# Altium schematic sheet 01_Power_Control.SchDoc(01_Power_Control)
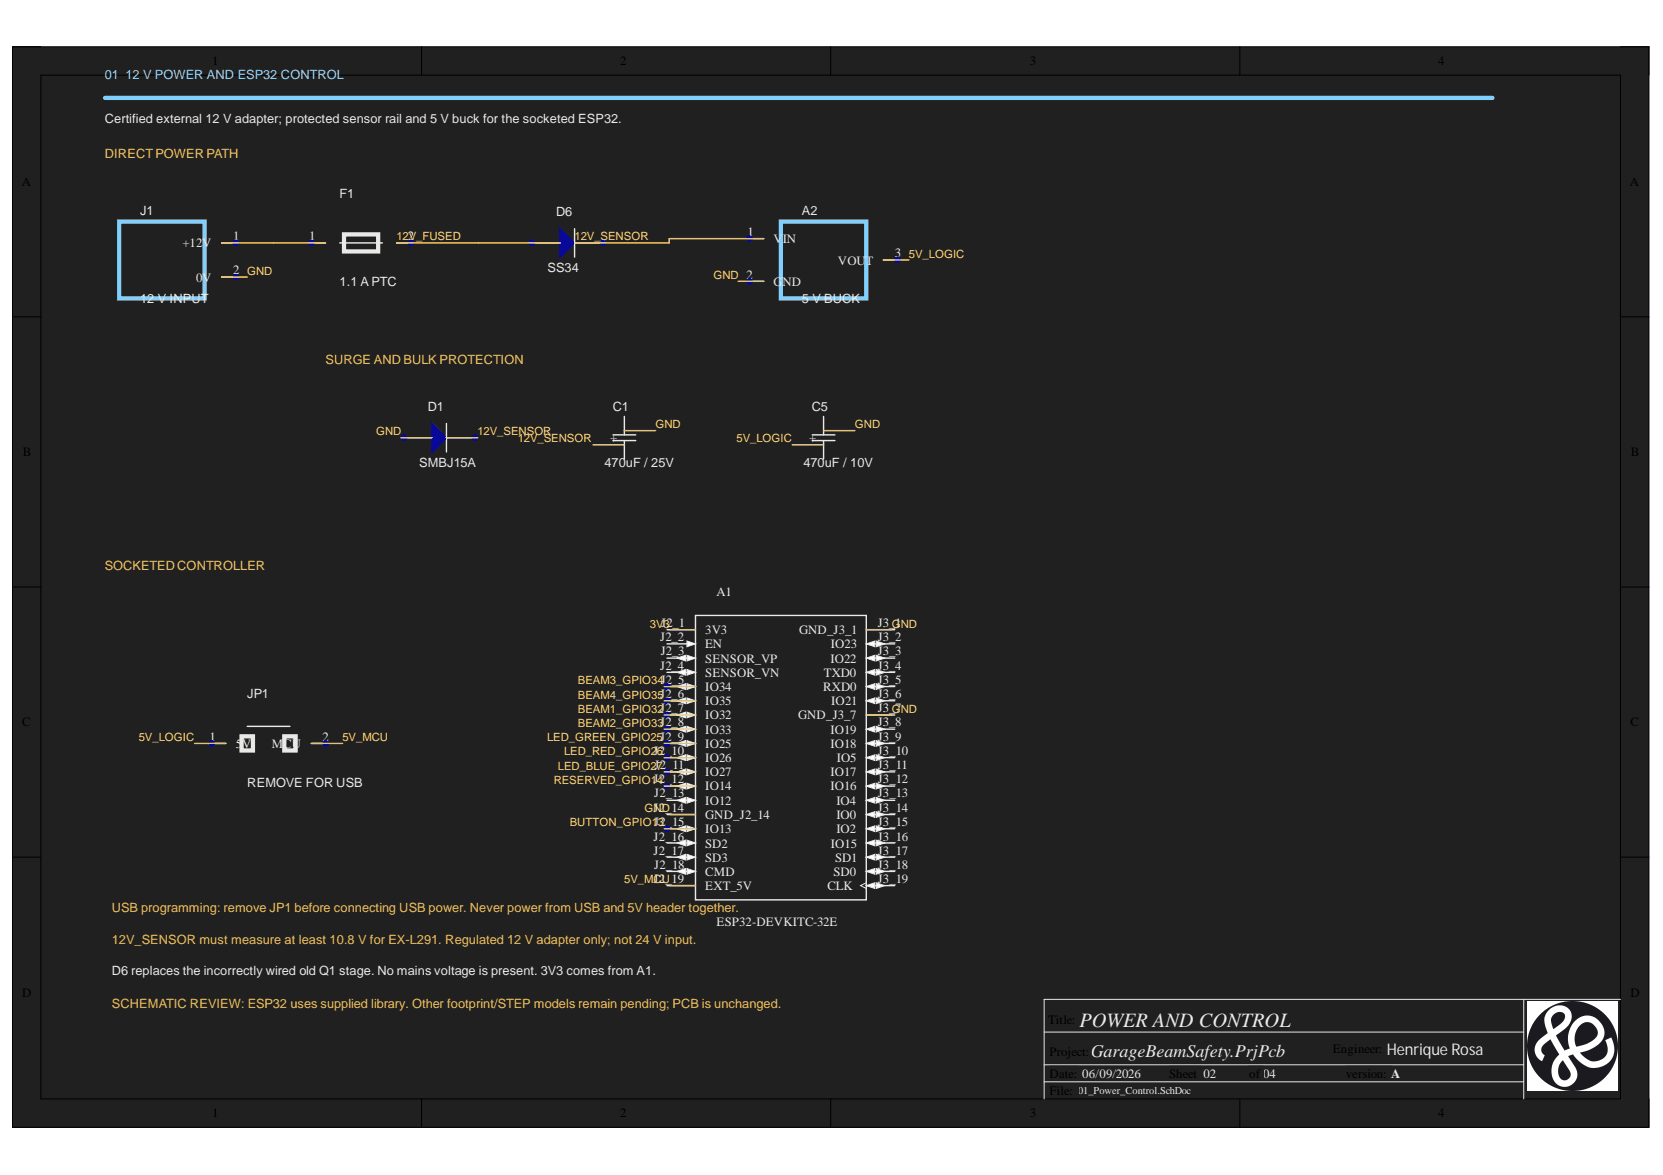
[diagram p0: 01_Power_Control.SchDoc]

## Parts
A1  ESP32-DEVKITC-32E  [MODULE_ESP32-DEVKITC-32E]
A2  5 V BUCK
C1  470uF / 25V
C5  470uF / 10V
D1  SMBJ15A
D6  SS34
F1  1.1 A PTC
J1  12 V INPUT
JP1  REMOVE FOR USB

## Nets
5V_LOGIC: A2.3 JP1.1
5V_MCU: JP1.2
12V_FUSED: D6.1 F1.2
12V_SENSOR: A2.1 D1.2 D6.2
BEAM1_GPIO32: A1.J2_7(IO32)
BEAM2_GPIO33: A1.J2_8(IO33)
BEAM3_GPIO34: A1.J2_5(IO34)
BEAM4_GPIO35: A1.J2_6(IO35)
BUTTON_GPIO13: A1.J2_15(IO13)
BUZZER_GPIO14: A1.J2_12(IO14)
GND: A2.2 D1.1 J1.2
LED_AMBER_GPIO27: A1.J2_11(IO27)
LED_GREEN_GPIO25: A1.J2_9(IO25)
LED_RED_GPIO26: A1.J2_10(IO26)
NetF1_1: F1.1 J1.1
3V3:

Not connected: A1 J2_1(3V3) J2_2(EN) J2_3(SENSOR_VP) J2_4(SENSOR_VN) J2_13(IO12) J2_14(GND_J2_14) J2_16(SD2) J2_17(SD3) J2_18(CMD) J2_19(EXT_5V) J3_1(GND_J3_1) J3_2(IO23) J3_3(IO22) J3_4(TXD0) J3_5(RXD0) J3_6(IO21) J3_7(GND_J3_7) J3_8(IO19) J3_9(IO18) J3_10(IO5) J3_11(IO17) J3_12(IO16) J3_13(IO4) J3_14(IO0) J3_15(IO2) J3_16(IO15) J3_17(SD1) J3_18(SD0) J3_19(CLK); C1 1 2; C5 1 2

## Library data of the parts
A1 = ESP32-DEVKITC-32E: The ESP32-DEVKITC-32E transceiver evaluation board from Espressif Systems is a powerful development tool for wireless communication technologies. It supports 802.11 b/g/n Wi-Fi and BluetoothÂ® Smart Ready 4.x (BLE) dual-mode at 2.4GHz, making it ideal for; CAD status: Supplied SnapMagic symbol and linked native footprint; Check_prices: https://www.snapeda.com/parts/ESP32-DEVKITC-32E/Espressif+Systems/view-part/?ref=eda; Component Kind: Standard; Manufacturer: Espressif Systems; Manufacturer Part Number: ESP32-DevKitC-32E; MF: Espressif Systems; MP: ESP32-DEVKITC-32E; Package: None; SnapEDA_Link: https://www.snapeda.com/parts/ESP32-DEVKITC-32E/Espressif+Systems/view-part/?ref=snap
A2 = (no symbol name): 12 V to regulated 5 V DC/DC module; Manufacturer: Murata; Manufacturer Part Number: OKI-78SR-5/1.5-W36-C; CAD status: Symbol ready; footprint and STEP verification pending; Intended footprint: SIP3_BUCK; Populate: Yes; Component Kind: Standard; Package: SIP3_BUCK
C1 = Capacitor Cerâmico SMD: Protected 12 V bulk capacitor; Manufacturer: Panasonic; Manufacturer Part Number: EEU-FR1E471; CAD status: Symbol ready; footprint and STEP verification pending; cod.: 186030; Intended footprint: CAP_RADIAL_10MM; Polarity: Pin 1 positive; pin 2 negative; Symbol source: Conventional symbol reused from ENERGY.SchDoc; Valor: 100nF; Component Kind: Standard; Library Name: Miscellaneous Devices.IntLib
C5 = Capacitor Cerâmico SMD: ESP32 Wi-Fi peak-current reservoir; Manufacturer: Panasonic; Manufacturer Part Number: EEU-FR1A471; CAD status: Symbol ready; footprint and STEP verification pending; cod.: 186030; Intended footprint: CAP_RADIAL_10MM; Polarity: Pin 1 positive; pin 2 negative; Symbol source: Conventional symbol reused from ENERGY.SchDoc; Valor: 100nF; Component Kind: Standard; Library Name: Miscellaneous Devices.IntLib
D1 = Diode: 15 V TVS suppressor - cathode to positive rail; Manufacturer: Littelfuse; Part Number: FDLL4148; CAD status: Symbol ready; footprint and STEP verification pending; Intended footprint: SMB; Symbol source: Conventional symbol reused from ENERGY.SchDoc; Code_JEDEC: DO-214-AB; Component Kind: Standard; LatestRevisionDate: 17-Jul-2002; LatestRevisionNote: Re-released for DXP Platform.; Library Name: Miscellaneous Devices.IntLib; Manufacturer Part Number: SMBJ15A; PackageDocument: Sep-1996; PackageReference: SMC; Value: value
D6 = Diode: Series reverse-polarity Schottky diode; Manufacturer: Vishay; Manufacturer Part Number: SS34-E3/57T; Part Number: FDLL4148; CAD status: Symbol ready; footprint and STEP verification pending; Intended footprint: SMC; Symbol source: Conventional symbol reused from ENERGY.SchDoc; Code_JEDEC: DO-214-AB; Component Kind: Standard; LatestRevisionDate: 17-Jul-2002; LatestRevisionNote: Re-released for DXP Platform.; Library Name: Miscellaneous Devices.IntLib; PackageDocument: Sep-1996; PackageReference: SMC; Value: value
F1 = (no symbol name): Resettable 12 V input fuse; Manufacturer: Bourns; Manufacturer Part Number: MF-MSMF110-2; CAD status: Symbol ready; footprint and STEP verification pending; Intended footprint: F1812; Populate: Yes; Component Kind: Standard; Package: F1812
J1 = (no symbol name): Two-position 5.08 mm screw terminal; Manufacturer: Phoenix Contact; Manufacturer Part Number: 1729128; CAD status: Symbol ready; footprint and STEP verification pending; Intended footprint: TERM_2_508; Populate: Yes; Component Kind: Standard; Package: TERM_2_508
JP1 = (no symbol name): External 5 V disconnect; fit shunt only without USB; Manufacturer: Samtec; Manufacturer Part Number: TSW-102-07-G-S; CAD status: Symbol ready; footprint and STEP verification pending; Intended footprint: HEADER_1X02_2.54; Populate: Yes; Component Kind: Standard; Package: HEADER_1X02_2.54
